# Altium schematic sheet 04 - QSPI FLASH, eMMC.SchDoc("04 - QSPI FLASH, eMMC")
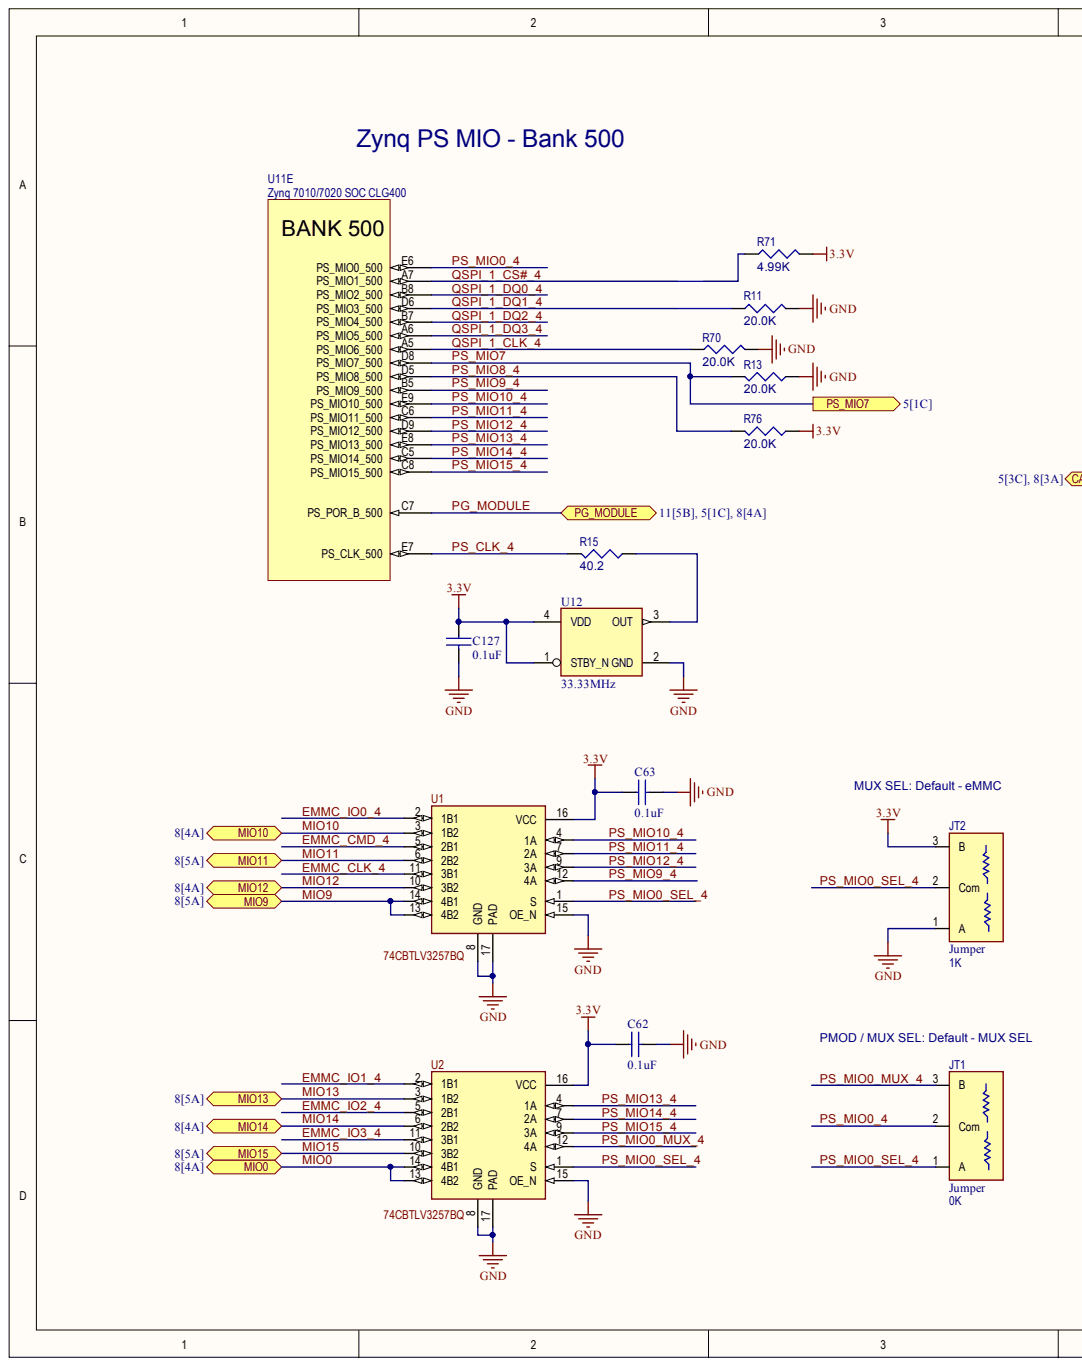
[diagram p0: 04 - QSPI FLASH, eMMC.SchDoc, left]
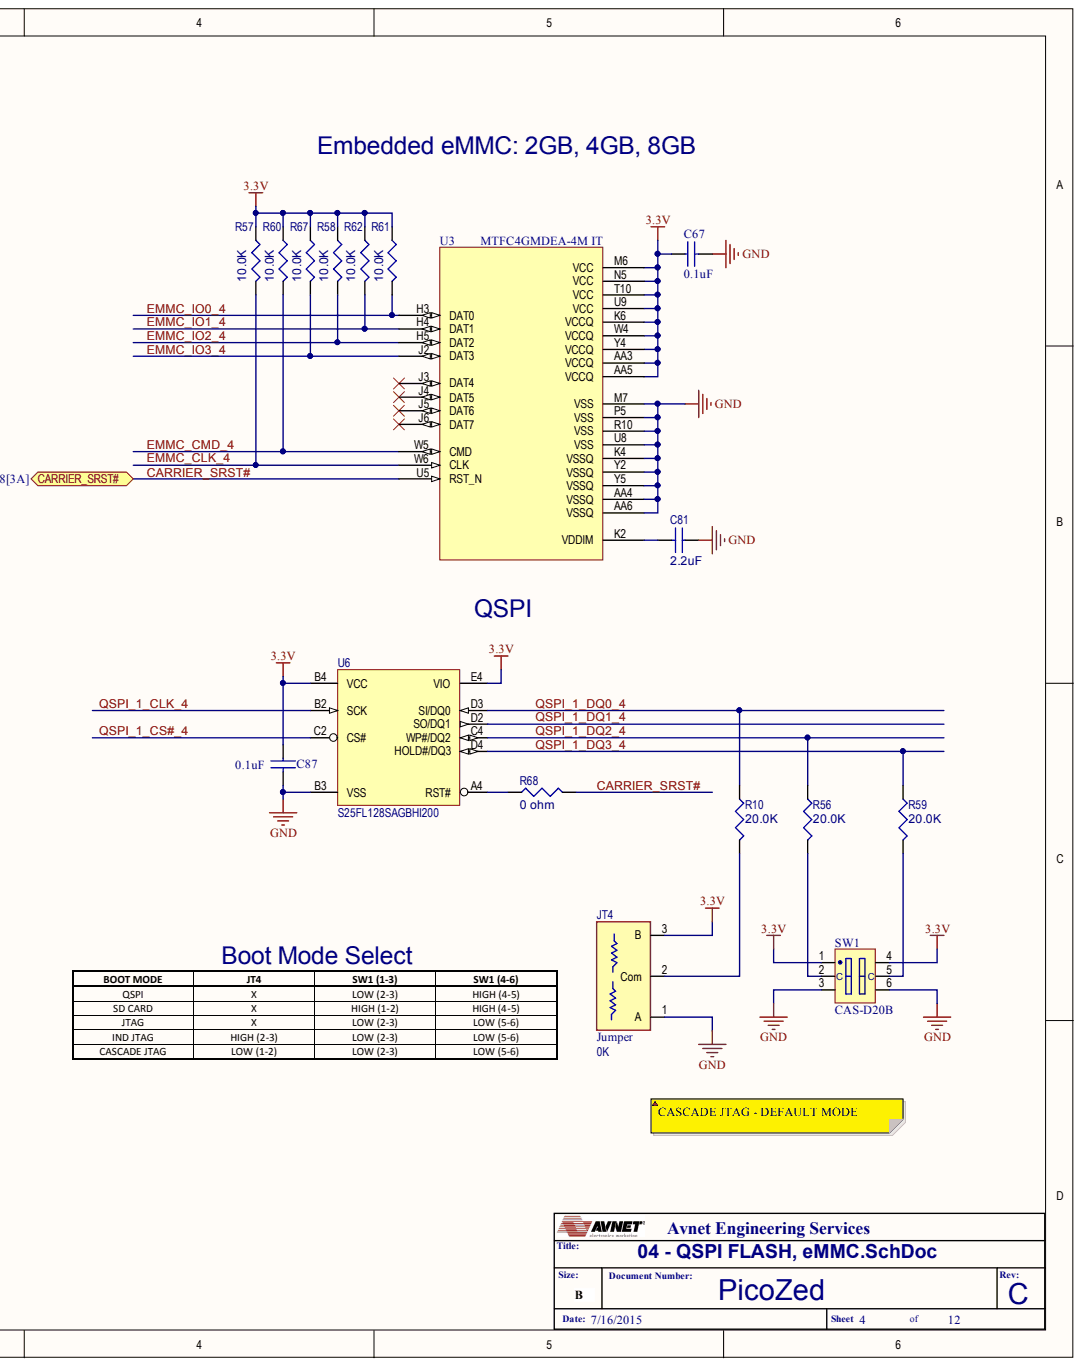
[diagram p1: 04 - QSPI FLASH, eMMC.SchDoc, right]

## Parts
C62  value 0.1uF  [C0201]  (hidden comment: PC522)
C63  value 0.1uF  [C0201]  (hidden comment: PC522)
C67  value 0.1uF  [C0201]  (hidden comment: PC522)
C81  2.2uF  [C0603]
C87  value 0.1uF  [C0201]  (hidden comment: PC522)
C127  value 0.1uF  [C0201]  (hidden comment: PC522)
JT1  value 0K  Device: Jumper  [R0402-DUAL_JMP]
JT2  value 1K  Device: Jumper  [R0402-DUAL_JMP]
JT4  value 0K  Device: Jumper  [R0402-DUAL_JMP]
R10  20.0K  [R0402]
R11  20.0K  [R0402]
R13  20.0K  [R0402]
R15  40.2  [R0402]
R56  20.0K  [R0402]
R57  10.0K  [R0402]
R58  10.0K  [R0402]
R59  20.0K  [R0402]
R60  10.0K  [R0402]
R61  10.0K  [R0402]
R62  10.0K  [R0402]
R67  10.0K  [R0402]
R68  0 ohm  [R0402]
R70  20.0K  [R0402]
R71  4.99K  [R0402]
R76  20.0K  [R0402]
SW1  CAS-D20B  [CDA-D20B]
U1  74CBTLV3257BQ  [QFN16-0.5mm]
U2  74CBTLV3257BQ  [QFN16-0.5mm]
U3  MFGPN: MTFC4GMDEA-4M IT  (hidden comment: MTFCxGxDxx-4M IT)
U6  S25FL128SAGBHI200  [BGA24_1MM_5X5]
U11  Zynq 7010/7020 SOC CLG400  [BGA400C80P20X20_1700X1700X160]  (part E)
U12  33.33MHz  [XTL_DSC1001-2.5X2.0]

## Ports
CARRIER_SRST#, MIO0, MIO9, MIO10, MIO11, MIO12, MIO13, MIO14, MIO15, PG_MODULE, PS_MIO7

## Nets
3.3V: C62.1 C63.1 C67.1 C87.1 C127.1 JT2.3 JT4.3 R57.1 R58.1 R60.1 R61.1 R62.1 R67.1 R71.2 R76.1 SW1.1 SW1.4 U1.16(VCC) U2.16(VCC) U3.AA3(VCCQ) U3.AA5(VCCQ) U3.K6(VCCQ) U3.M6(VCC) U3.N5(VCC) U3.T10(VCC) U3.U9(VCC) U3.W4(VCCQ) U3.Y4(VCCQ) U6.B4(VCC) U6.E4(VIO) U12.1(STBY_N) U12.4(VDD)
GND: C62.2 C63.2 C67.2 C81.1 C87.2 C127.2 JT2.1 JT4.1 R11.2 R13.1 R70.2 SW1.3 SW1.6 U1.8(GND) U1.15(OE_N) U1.17(PAD) U2.8(GND) U2.15(OE_N) U2.17(PAD) U3.AA4(VSSQ) U3.AA6(VSSQ) U3.K4(VSSQ) U3.M7(VSS) U3.P5(VSS) U3.R10(VSS) U3.U8(VSS) U3.Y2(VSSQ) U3.Y5(VSSQ) U6.B3(VSS) U12.2(GND)
CARRIER_SRST#: R68.2 U3.U5(RST_N) ; port CARRIER_SRST#
EMMC_CLK_4: R57.2 U1.11(3B1) U3.W6(CLK)  [also labelled EMMC_CLK]
EMMC_CMD_4: R60.2 U1.5(2B1) U3.W5(CMD)  [also labelled EMMC_CMD]
EMMC_IO0_4: R61.2 U1.2(1B1) U3.H3(DAT0)  [also labelled EMMC_IO0]
EMMC_IO1_4: R62.2 U2.2(1B1) U3.H4(DAT1)  [also labelled EMMC_IO1]
EMMC_IO2_4: R58.2 U2.5(2B1) U3.H5(DAT2)  [also labelled EMMC_IO2]
EMMC_IO3_4: R67.2 U2.11(3B1) U3.J2(DAT3)  [also labelled EMMC_IO3]
MIO0: U2.13(4B2) U2.14(4B1) ; port MIO0
MIO9: U1.13(4B2) U1.14(4B1) ; port MIO9
MIO10: U1.3(1B2) ; port MIO10
MIO11: U1.6(2B2) ; port MIO11
MIO12: U1.10(3B2) ; port MIO12
MIO13: U2.3(1B2) ; port MIO13
MIO14: U2.6(2B2) ; port MIO14
MIO15: U2.10(3B2) ; port MIO15
PG_MODULE: U11.C7(PS_POR_B_500) ; port PG_MODULE
PS_CLK_4: R15.2 U11.E7(PS_CLK_500)  [also labelled PS_CLK]
PS_MIO0_4: JT1.2 U11.E6(PS_MIO0_500)  [also labelled PS_MIO0]
PS_MIO0_MUX_4: JT1.3 U2.12(4A)  [also labelled PS_MIO0_MUX]
PS_MIO0_SEL_4: JT1.1 JT2.2 U1.1(S) U2.1(S)  [also labelled PS_MIO0_SEL]
PS_MIO7: R13.2 U11.D8(PS_MIO7_500) ; port PS_MIO7
PS_MIO8_4: R76.2 U11.D5(PS_MIO8_500)  [also labelled PS_MIO8]
PS_MIO9_4: U1.12(4A) U11.B5(PS_MIO9_500)  [also labelled PS_MIO9]
PS_MIO10_4: U1.4(1A) U11.E9(PS_MIO10_500)  [also labelled PS_MIO10]
PS_MIO11_4: U1.7(2A) U11.C6(PS_MIO11_500)  [also labelled PS_MIO11]
PS_MIO12_4: U1.9(3A) U11.D9(PS_MIO12_500)  [also labelled PS_MIO12]
PS_MIO13_4: U2.4(1A) U11.E8(PS_MIO13_500)  [also labelled PS_MIO13]
PS_MIO14_4: U2.7(2A) U11.C5(PS_MIO14_500)  [also labelled PS_MIO14]
PS_MIO15_4: U2.9(3A) U11.C8(PS_MIO15_500)  [also labelled PS_MIO15]
QSPI_1_CLK_4: R70.1 U6.B2(SCK) U11.A5(PS_MIO6_500)  [also labelled QSPI_1_CLK]
QSPI_1_CS#_4: R71.1 U6.C2(CS#) U11.A7(PS_MIO1_500)  [also labelled QSPI_1_CS#]
QSPI_1_DQ0_4: R10.1 U6.D3(SI/DQ0) U11.B8(PS_MIO2_500)  [also labelled QSPI_1_DQ0]
QSPI_1_DQ1_4: R11.1 U6.D2(SO/DQ1) U11.D6(PS_MIO3_500)  [also labelled QSPI_1_DQ1]
QSPI_1_DQ2_4: R56.1 U6.C4(WP#/DQ2) U11.B7(PS_MIO4_500)  [also labelled QSPI_1_DQ2]
QSPI_1_DQ3_4: R59.1 U6.D4(HOLD#/DQ3) U11.A6(PS_MIO5_500)  [also labelled QSPI_1_DQ3]
NetC81_2: C81.2 U3.K2(VDDIM)
NetJT4_2: JT4.2 R10.2
NetR15_1: R15.1 U12.3(OUT)
NetR56_2: R56.2 SW1.2(C)
NetR59_2: R59.2 SW1.5(C)
NetR68_1: R68.1 U6.A4(RST#)

Not connected: U3 J3(DAT4) J4(DAT5) J5(DAT6) J6(DAT7)

## Library data of the parts
C62 C63 C67 C87 C127 = AVPC-0000522: CAP SM 0.1uF 10V 10% X5R C0201; PartCode: PC; Database Table Name: Passive-Cap$; MFG Code: AVX; MFGPN: 02016D104KAT2A; AsyTxt: PC522; AVLNo: AVPC-0000522; Component Kind: Standard; ComponentLink1Description: Datasheet; Device: CAP; Dielectric: X5R; PkgType: SM; RoHS: Yes; Status: Preliminary; Tol: 10%; Volt: 10V; ComponentLink1URL: C:\AVDBLIB\DATASHEETS\AVX_CCOG.pdf; Library Name: Avnet_DBlib.DbLib
C81 = AVPC-0000155: CAP SM 2.2uF 6.3V 10% X5R C0603; PartCode: PC; AsyTxt: PC155; Database Table Name: Passive-Cap$; MFG Code: AVX; MFGPN: 06036D225KAT2A; Power: 0; Availability: 4K-AV; AVLNo: AVPC-0000155; Component Kind: Standard; ComponentLink1Description: Datasheet; ComponentLink2Description: MFR Website; ComponentLink2URL: www.avx.com; Cost: 0.04; Device: CAP; Dielectric: X5R; PkgType: SM; Received: 0; RoHS: Yes; Size: 3.4; Status: Preliminary; Tol: 10%; Value: 2.2uF; Volt: 6.3V; ComponentLink1URL: C:\AVDBLIB\DATASHEETS\AVX_CX5R.pdf; Library Name: Avnet_DBlib.DbLib
JT1 JT4 = AVPO-0000025: Jumper 3-pad 0402 RES - 0 Ohm : Pads 1-2; PartCode: PO; Database Table Name: Passive-Other$; Device Type: 3-pad 0R0 0402; MFG Code: DAL; MFGPN: CRCW04020000Z0ED; Note: Place Pads: 1-2; PkgType: SM; Component Kind: Standard; ComponentLink1Description: Datasheet; Part #: CRCW04020000Z0ED; RoHS: Yes; Status: Preliminary; ComponentLink1URL: C:\AVDBLIB\DATASHEETS\Dale_CRCW.pd; Library Name: Avnet_DBlib.DbLib
JT2 = AVPO-0000025: Jumper 3-pad 0402 RES - 1K-Ohm : Pads 1-2; PartCode: PO; Database Table Name: Passive-Other$; Device Type: 3-pad 0R0 0402; MFG Code: DAL; MFGPN: CRCW04021K00FKED; Note: Place Pads: 1-2; PkgType: SM; Component Kind: Standard; ComponentLink1Description: Datasheet; RoHS: Yes; Status: Preliminary; ComponentLink1URL: C:\AVDBLIB\DATASHEETS\Dale_CRCW.pd; Library Name: Avnet_DBlib.DbLib
R10 R56 R59 = AVPR-0000061: RES SM 20.0K 62mW 0.01 R0402; PartCode: PR; Database Table Name: Passive-Res$; MFG Code: DAL; MFGPN: CRCW040220K0FKED; Tol: 0.01; Component Kind: Standard; Device: RES; PkgType: SM; RoHS: Yes; Status: Preliminary; Value: 20.0K; Watt: 62mW; Library Name: Avnet_DBlib.DbLib
R11 R13 R70 R76 = AVPR-0000061: RES SM 20.0K 62mW 0.01 R0402; PartCode: PR; AsyTxt: PR061; Database Table Name: Passive-Res$; MFG Code: DAL; MFGPN: CRCW040220K0FKED; Tol: 0.01; AVLNo: AVPR-0000061; Component Kind: Standard; ComponentLink1Description: Datasheet; Device: RES; PkgType: SM; RoHS: Yes; Status: Preliminary; Value: 20.0K; Watt: 62mW; ComponentLink1URL: C:\AVDBLIB\DATASHEETS\Dale_CRCW.pd; Library Name: Avnet_DBlib.DbLib
R15 = (no symbol name): RES SM 40.2 62mW 0.01 R0402; PartCode: PR; AsyTxt: PR092; MFG Code: DAL; MFGPN: CRCW040240R2FKED; Power: 0; Tol: 0.01; Watt: 63mW; Availability: 2M-AV; CLASSNAME: DDR_TERMR; Component Kind: Standard; ComponentLink1Description: Datasheet; Cost: .01; Device: RES; Library Name: Z7045_MM.SchLib; PkgType: SM; Received: 0; RoHS: Yes; Size: 1.9; Status: Preliminary; Value: 40.2; Watt: 62mW; ComponentLink1URL: C:\AVDBLIB\DATASHEETS\Dale_CRCW.pd
R57 R58 R60-R62 R67 = AVPR-0000061: RES SM 10.0K 62mW 0.01 R0402; PartCode: PR; AsyTxt: PR061; Database Table Name: Passive-Res$; MFG Code: DAL; MFGPN: CRCW040210K0FKED; Tol: 0.01; AVLNo: AVPR-0000061; Component Kind: Standard; ComponentLink1Description: Datasheet; Device: RES; PkgType: SM; RoHS: Yes; Status: Preliminary; Value: 10.0K; Watt: 62mW; ComponentLink1URL: C:\AVDBLIB\DATASHEETS\Dale_CRCW.pd; Library Name: Avnet_DBlib.DbLib
R68 = AVPR-0000027: RES SM 0 ohm 62mW J R0402; PartCode: PR; AsyTxt: PR027; Database Table Name: Passive-Res$; MFG Code: DAL; MFGPN: CRCW04020000Z0ED; AVLNo: AVPR-0000027; Component Kind: Standard; ComponentLink1Description: Datasheet; Device: RES; PkgType: SM; RoHS: Yes; Status: Preliminary; Value: 0 ohm; Watt: 62mW; ComponentLink1URL: C:\AVDBLIB\DATASHEETS\Dale_CRCW.pd; Library Name: Avnet_DBlib.DbLib
R71 = AVPR-0000094: RES SM 4.99K 62mW 0.01 R0402; PartCode: PR; AsyTxt: PR094; Database Table Name: Passive-Res$; MFG Code: DAL; MFGPN: CRCW04024K99FKED; Tol: 0.01; AVLNo: AVPR-0000094; Component Kind: Standard; ComponentLink1Description: Datasheet; Device: RES; PkgType: SM; RoHS: Yes; Status: Preliminary; Value: 4.99K; Watt: 62mW; ComponentLink1URL: C:\AVDBLIB\DATASHEETS\Dale_CRCW.pd; Library Name: Avnet_DBlib.DbLib
SW1 = CAS-D20B: SLIDE SWITCH SPDT 2POS 6V; MFG Code: COPAL; Component Kind: Standard; Library Name: new.SchLib; MFGPN: CAS-D20B; Part #: CAS-D20B
U1 U2 = 74CBTLV3257BQ: Quad 1-of-2 Multiplexer/Demultiplexer; MFG Code: NXP; Component Kind: Standard; Library Name: new.SchLib; MFGCODE: NXP; MFGPN: 74CBTLV3257BQ; Status: Preliminary
U3 = eMMC: eMMC Memory: 4GB/8GB/16GB/32GB/64GB, 153-ball/169-ball FBGA, 3.3V; MFG Code: MIC; MFGPN_ALT1: MTFC8GLDEA-4M IT; Temp: Industrial; Component Kind: Standard; Library Name: new.SchLib; MFGPN_ALT2: MTFC16GJDEC-4M IT; MFGPN_ALT3: MTFC32GJDED-4M IT; MFGPN_ALT4: MTFC64GJDDN-4M IT
U6 = S25FL128SAGBHI200: NOR Flash Serial-SPI 3V/3.3V 128Mbit 128M x 1bit 8ns 24-Pin BGA; AVLNo: AVIM-0000100; MFG Code: SPN; CLassName: BGA; Component Kind: Standard; Library Name: Zynq_Z010_ZedStick.SchLib; MFGPN: S25FL128SAGBHI200
U11 = Zynq Z010/Z020 SOC CLG400: MFG Code: XLX; MFGPN: XC7Z010-1CLG400C; CLASSNAME: BGA; Component Kind: Standard; Description: Zynq 7010/7020 SOC CLG400; Library Name: Zynq_Z010_ZedStick.SchLib
U12 = DSC1001DI1-033.3300: OSC, SM, Abracon, 1.8V 33.3300MHz, 50ppm,  2.5x2.0mm; AVLNo: AVIT-0000119; MFGPN: ASDMB-33.333MHZ-LC-T; Component Kind: Standard; Library Name: Zynq_Z010_ZedStick.SchLib; MFG Code: ABRACON
